# Altium schematic sheet top.SchDoc
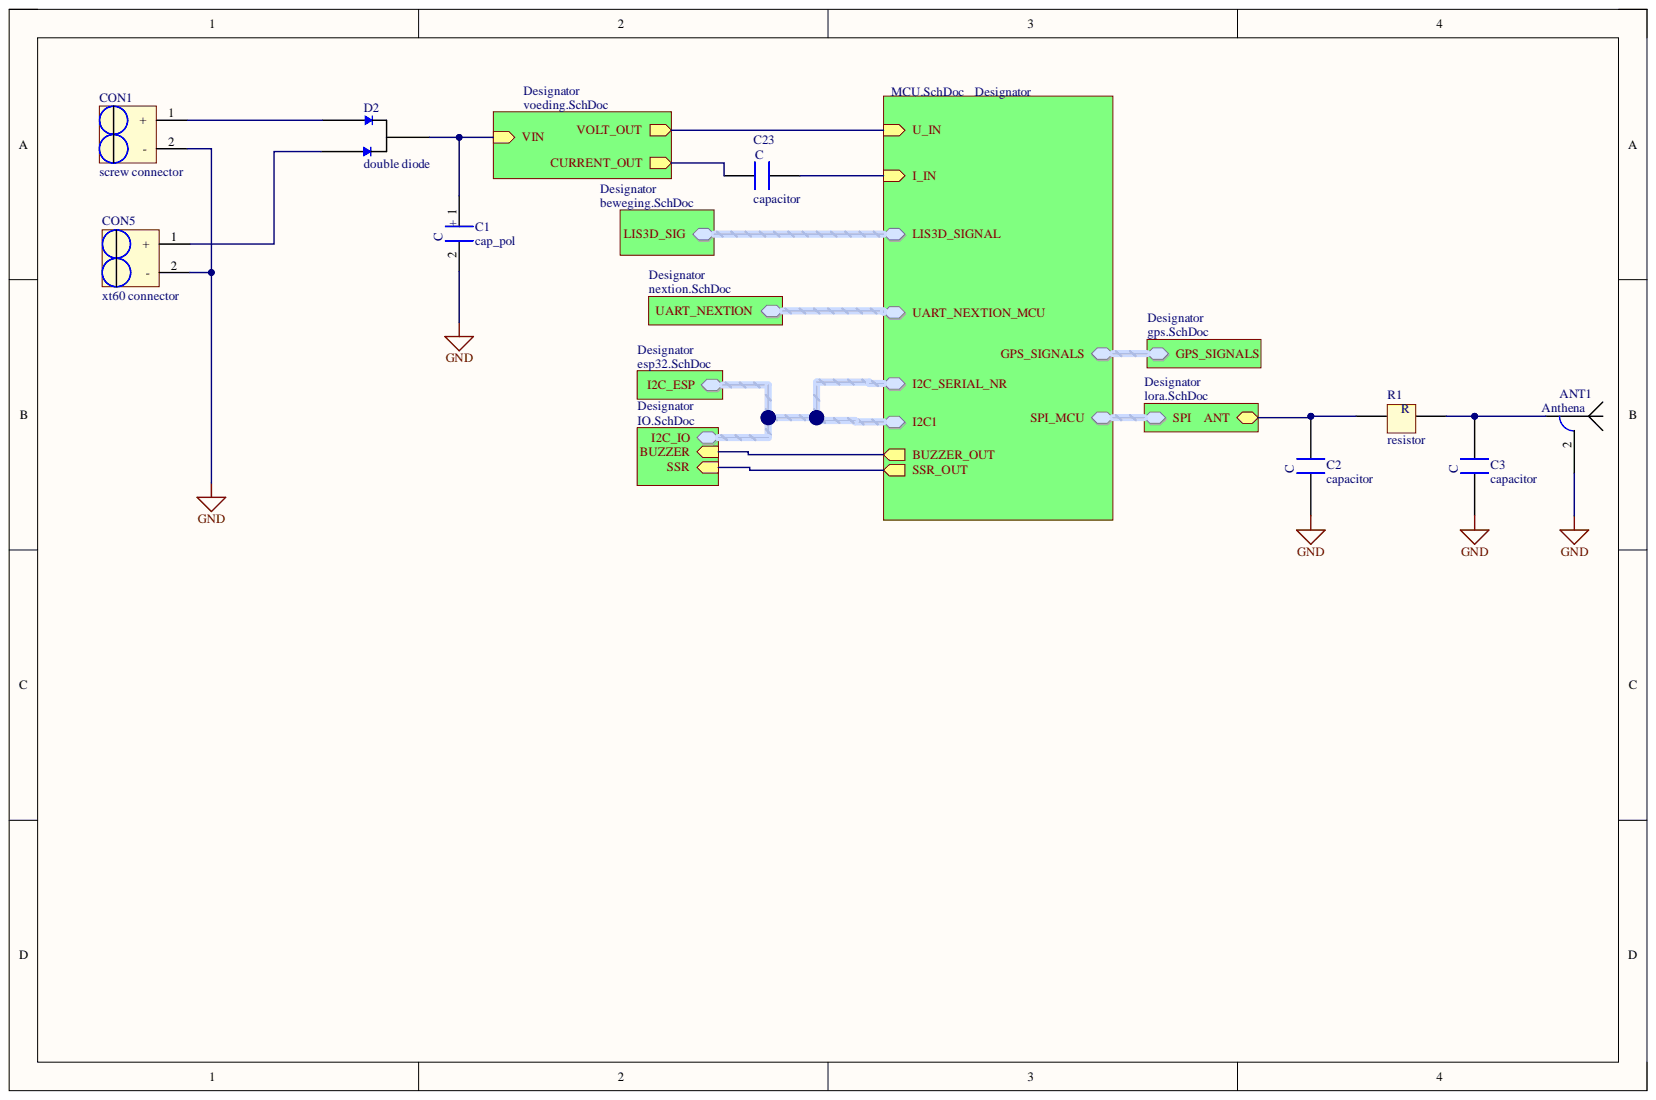
[diagram p0: top.SchDoc]

## Parts
ANT1  Anthena  [sma connector]
C1  cap_pol  [1206]
C2  capacitor  [0603]
C3  capacitor  [0603]
C23  capacitor  [0603]
CON1  screw connector  [SCREW connector 5mm pitch]
CON5  xt60 connector
D2  double diode  [TO-263AB]
R1  resistor  [0603]

## Nets
GND: ANT1.2 C1.2 C2.2 C3.2 CON1.2 CON5.2
NetANT1_1: ANT1.1 C3.1 R1.1
NetC1_1: C1.1 D2.3
NetC2_1: C2.1 R1.2
NetC23_1: C23.1
NetC23_2: C23.2
NetCON1_1: CON1.1 D2.1
NetCON5_1: CON5.1 D2.2
BUZZER:
GPS_SIGNALS.PPS:
GPS_SIGNALS.RX:
GPS_SIGNALS.SYS_RESET:
GPS_SIGNALS.TX:
GPS_SIGNALS.WAKE:
I2C1.SCL1:
I2C1.SDA1:
LIS3D_SIG.INT1_LIS3D:
LIS3D_SIG.INT2_LIS3D:
LIS3D_SIG.SCL_LIS3D:
LIS3D_SIG.SDA_LIS3D:
SPI.MISO:
SPI.MOSI:
SPI.NSS:
SPI.RESET:
SPI.SCK:
SSR:
U_IN:
UART_NEXTION.RX_NEXTION:
UART_NEXTION.TX_NEXTION:

## Library data of the parts
CON5 = screw connector: Component Kind: Standard; Description: screw connector; Library Name: conector's_and_TP.SchLib
ANT1 = Anthena: Component Kind: Standard; Library Name: pasives.SchLib
C1 = cap_pol: Component Kind: Standard; Library Name: pasives.SchLib
C2 C3 C23 = capacitor: Component Kind: Standard; Library Name: pasives.SchLib
CON1 = screw connector: Component Kind: Standard; Description: screw connector; Library Name: conector's_and_TP.SchLib
D2 = double diode: Component Kind: Standard; Library Name: halfgelijders.SchLib
R1 = resistor: Component Kind: Standard; Library Name: pasives.SchLib
